# Altium schematic sheet MainSchematic.SchDoc
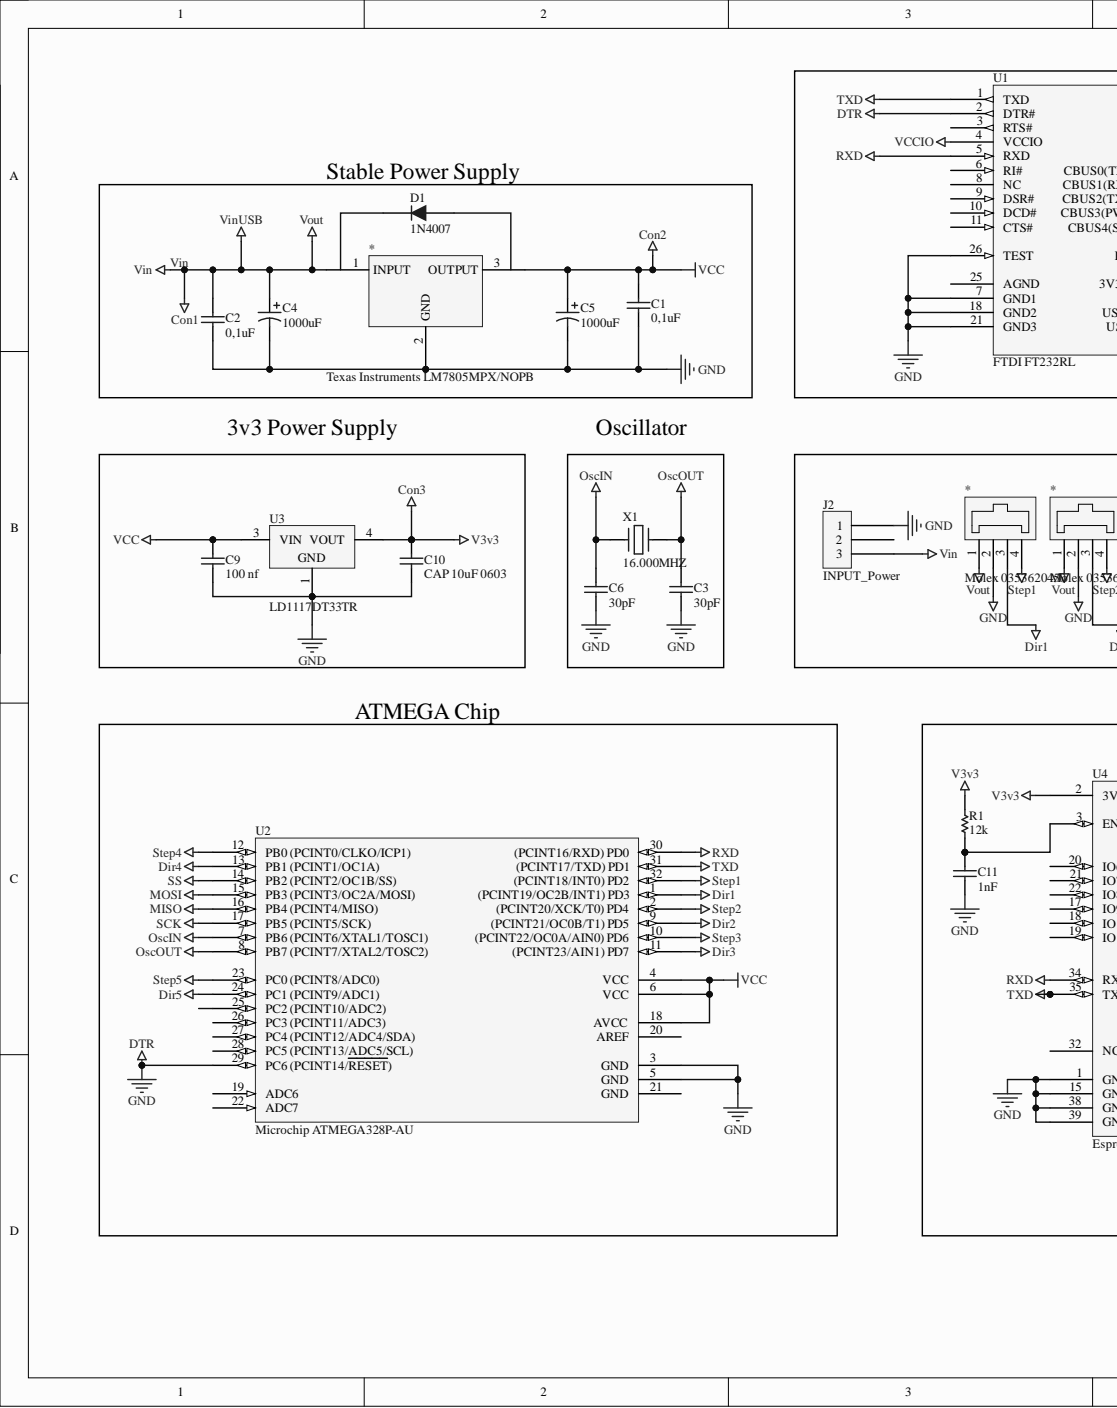
[diagram p0: MainSchematic.SchDoc, left]
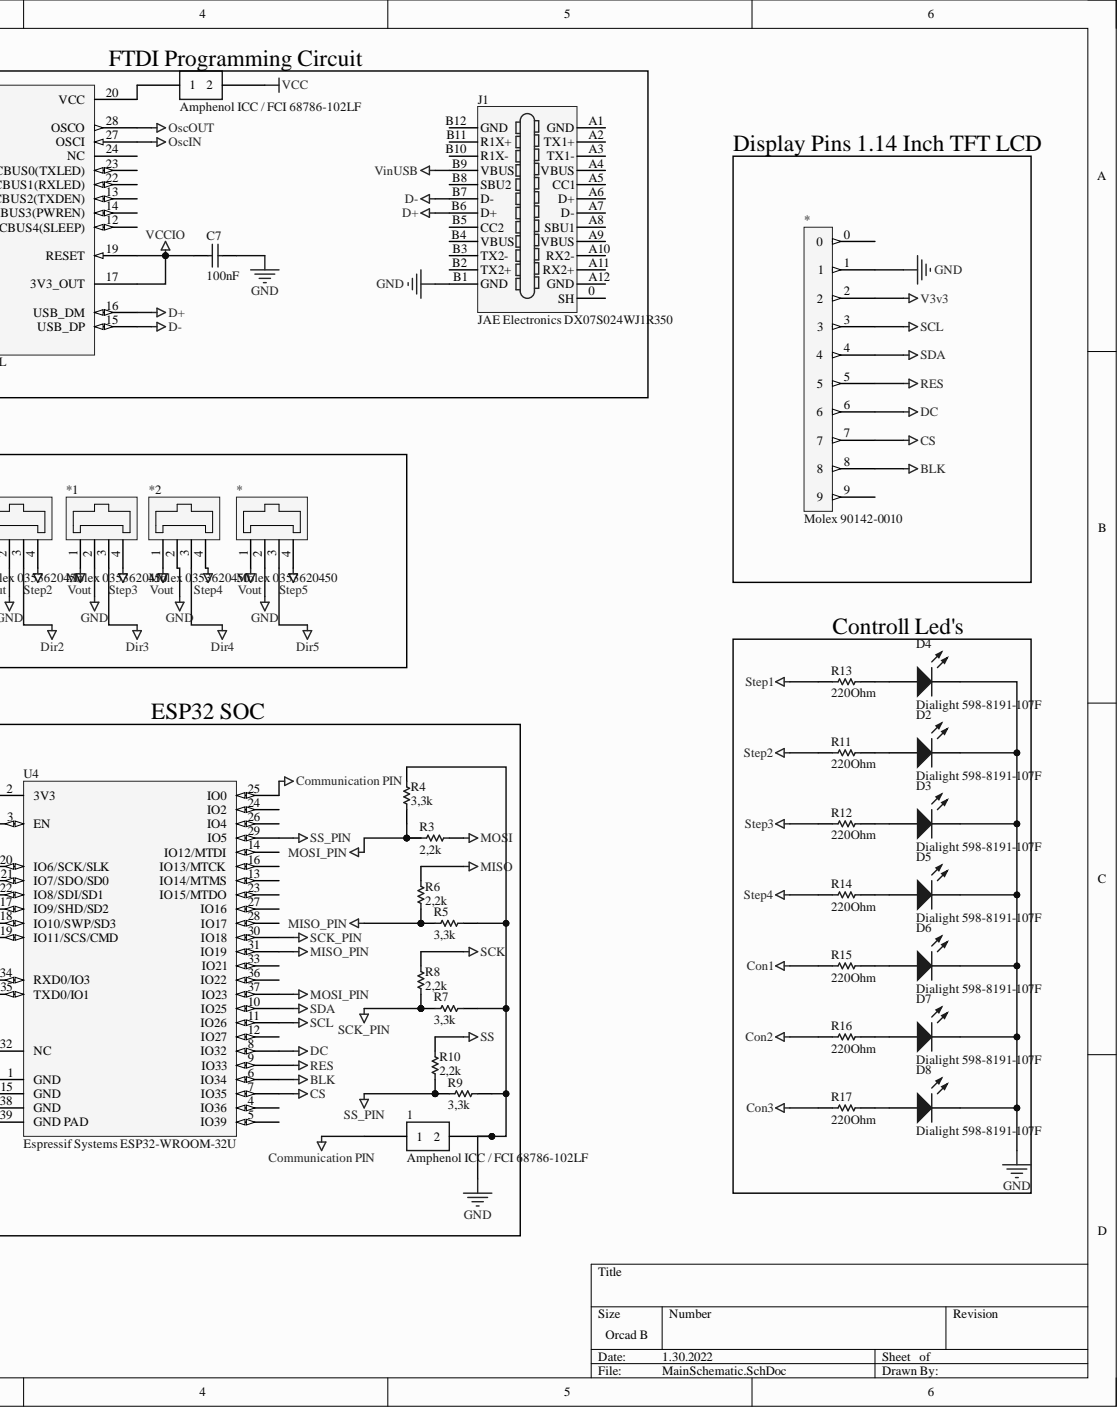
[diagram p1: MainSchematic.SchDoc, right]

## Parts
1  Amphenol ICC / FCI 68786-102LF  Manufacturer Part Number: 68786-102LF  [Amphenol ICC _ FCI 68786-102LF-Footprint-1]
*  Molex 0353620450  Manufacturer Part Number: 0353620450  [PCB-dbci3ib1q6wlta0cp8e4-1]
*  Molex 0353620450  Manufacturer Part Number: 0353620450  [PCB-dbci3ib1q6wlta0cp8e4-1]
*  Molex 0353620450  Manufacturer Part Number: 0353620450  [PCB-dbci3ib1q6wlta0cp8e4-1]
*  Molex 90142-0010  [Molex 90142-0006-Footprint-2]
*  Texas Instruments LM7805MPX/NOPB  Manufacturer Part Number: LM7805MPX/NOPB  [PCB-4j8pz0fvsyjao2wd9t55-1]
*1  Molex 0353620450  Manufacturer Part Number: 0353620450  [PCB-dbci3ib1q6wlta0cp8e4-1]
*2  Molex 0353620450  Manufacturer Part Number: 0353620450  [PCB-dbci3ib1q6wlta0cp8e4-1]
C1  0,1uF  [PCB-ygfjbn9s2h0hdffghsun-1]
C2  0,1uF  [PCB-ygfjbn9s2h0hdffghsun-1]
C3  30pF  [CAPC1608X92X38ML15T08]
C4  1000uF  [PCB-6nlarhmhqnsp8hp5opt1-1]
C5  1000uF  [PCB-6nlarhmhqnsp8hp5opt1-1]
C6  30pF  [CAPC1608X92X38ML15T08]
C7  100nF  [PCB-ami98eyguu81f02oljzp-1]
C9  100 nf  [PCB-ygyach2j9q55hm6do3nn-1]
C10  CAP 10uF 0603  value 10uF  [PCB-wvd42uoi0udsmgfukqhe-1]
C11  1nF  [CAPC3216X88X50NL20T25]
D1  1N4007  [PCB-kzeakw2snxr54lsx6p55-1]
D2  Dialight 598-8191-107F  Manufacturer Part Number: 598-8191-107F  [PCB-8gfa86omdps060zikj8u-1]
D3  Dialight 598-8191-107F  Manufacturer Part Number: 598-8191-107F  [PCB-8gfa86omdps060zikj8u-1]
D4  Dialight 598-8191-107F  Manufacturer Part Number: 598-8191-107F  [PCB-8gfa86omdps060zikj8u-1]
D5  Dialight 598-8191-107F  Manufacturer Part Number: 598-8191-107F  [PCB-8gfa86omdps060zikj8u-1]
D6  Dialight 598-8191-107F  Manufacturer Part Number: 598-8191-107F  [PCB-8gfa86omdps060zikj8u-1]
D7  Dialight 598-8191-107F  Manufacturer Part Number: 598-8191-107F  [PCB-8gfa86omdps060zikj8u-1]
D8  Dialight 598-8191-107F  Manufacturer Part Number: 598-8191-107F  [PCB-8gfa86omdps060zikj8u-1]
J1  JAE Electronics DX07S024WJ1R350  Manufacturer: JAE Electronics  Manufacturer Part Number: DX07S024WJ1R350  [PCB-c92dq56pmj9hhsl6x7py-1]
J2  INPUT_Power  [PCB-6ccby9ci1emhqvhnf7pu-1]
R1  12k  [PCB-ag4xodjlqx01vhs1m206-1]
R3  2,2k  [RESC1005X37X25LL05T10]
R4  3,3k  [RESC1608X55X25LL10T15]
R5  3,3k  [RESC1608X55X25LL10T15]
R6  2,2k  [RESC1005X37X25LL05T10]
R7  3,3k  [RESC1608X55X25LL10T15]
R8  2,2k  [RESC1005X37X25LL05T10]
R9  3,3k  [RESC1608X55X25LL10T15]
R10  2,2k  [RESC1005X37X25LL05T10]
R11  220Ohm  [RESC1608X55X30LL15T15]
R12  220Ohm  [RESC1608X55X30LL15T15]
R13  220Ohm  [RESC1608X55X30LL15T15]
R14  220Ohm  [RESC1608X55X30LL15T15]
R15  220Ohm  [RESC1608X55X30LL15T15]
R16  220Ohm  [RESC1608X55X30LL15T15]
R17  220Ohm  [RESC1608X55X30LL15T15]
U1  FTDI FT232RL  Manufacturer Part Number: FT232RL  [PCB-uwrbgvod66r8ixue8rhm-1]
U2  Microchip ATMEGA328P-AU  [PCB-pmhtxxix7sripjdh33vl-1]
U3  LD1117DT33TR  [TO-252_N]
U4  Espressif Systems ESP32-WROOM-32U  [PCB-s9cahnfxwmssy5lrdql1-1]
X1  16.000MHZ  [PCB-m5wiadh0ra6h79h7klez-1]
  Amphenol ICC / FCI 68786-102LF  Manufacturer Part Number: 68786-102LF  [Amphenol ICC _ FCI 68786-102LF-Footprint-1]

## Nets
BLK: *.8 U4.6(IO34)
Communication PIN: 1.1 U4.25(IO0)
Con2: *.3 C1.1 C5.1 C9.2 R16.2 U2.4(VCC) U2.6(VCC) U2.18(AVCC) U3.3 .2
Con3: *.2 C10.2 R1.2 R17.2 U3.4 U4.2(3V3)
CS: *.7 U4.7(IO35)
D+: J1.B6(D+) U1.16(USB_DM)
D-: J1.B7(D-) U1.15(USB_DP)
DC: *.6 U4.8(IO32)
Dir1: *.3 U2.1((PCINT19/OC2B/INT1)_PD3)
Dir2: *.3 U2.9((PCINT21/OC0B/T1)_PD5)
Dir3: *1.3 U2.11((PCINT23/AIN1)_PD7)
Dir4: *2.3 U2.13(PB1_(PCINT1/OC1A))
Dir5: *.3 U2.24(PC1_(PCINT9/ADC1))
DTR: 1.2 *.1 *.2 *1.2 *2.2 C1.2 C2.1 C3.1 C4.2 C5.2 C6.1 C7.2 C9.1 C10.1 C11.2 D2.K D3.K D4.K D5.K D6.K D7.K D8.K J1.B1(GND) J2.1 R4.2 R5.1 R7.1 R9.1 U1.2(DTR#) U1.7(GND1) U1.18(GND2) U1.21(GND3) U1.26(TEST) U2.3(GND) U2.5(GND) U2.29(PC6_(PCINT14/RESET)) U3.1 U4.1(GND) U4.15(GND) U4.38(GND) U4.39(GND_PAD)
MISO: R6.1 U2.16(PB4_(PCINT4/MISO))
MISO_PIN: R5.2 R6.2 U4.31(IO19)
MOSI: R3.1 U2.15(PB3_(PCINT3/OC2A/MOSI))
MOSI_PIN: R3.2 R4.1 U4.37(IO23)
Net_1: U1.20(VCC) .1
OscIN: C6.2 U1.27(OSCI) U2.7(PB6_(PCINT6/XTAL1/TOSC1)) X1.1
OscOUT: C3.2 U1.28(OSCO) U2.8(PB7_(PCINT7/XTAL2/TOSC2)) X1.2
RES: *.5 U4.9(IO33)
RXD: U1.5(RXD) U2.30((PCINT16/RXD)_PD0) U4.34(RXD0/IO3)
SCK: R8.1 U2.17(PB5_(PCINT5/SCK))
SCK_PIN: R7.2 R8.2 U4.30(IO18)
SCL: *.3 U4.11(IO26)
SDA: *.4 U4.10(IO25)
SS: R10.1 U2.14(PB2_(PCINT2/OC1B/SS))
SS_PIN: R9.2 R10.2 U4.29(IO5)
Step1: *.4 R13.2 U2.32((PCINT18/INT0)_PD2)
Step2: *.4 R11.2 U2.2((PCINT20/XCK/T0)_PD4)
Step3: *1.4 R12.2 U2.10((PCINT22/OC0A/AIN0)_PD6)
Step4: *2.4 R14.2 U2.12(PB0_(PCINT0/CLKO/ICP1))
Step5: *.4 U2.23(PC0_(PCINT8/ADC0))
TXD: U1.1(TXD) U2.31((PCINT17/TXD)_PD1) U4.35(TXD0/IO1)
VCCIO: C7.1 U1.4(VCCIO) U1.17(3V3_OUT) U1.19(RESET)
Vin: *.1 *1.1 *2.1 C2.2 C4.1 J1.B9(VBUS) J2.3 R15.2
NetC11_1: C11.1 R1.1 U4.3(EN)
NetD2_A: D2.A R11.1
NetD3_A: D3.A R12.1
NetD4_A: D4.A R13.1
NetD5_A: D5.A R14.1
NetD6_A: D6.A R15.1
NetD7_A: D7.A R16.1
NetD8_A: D8.A R17.1

Not connected: * 0 9; D1 1 2; J1 0(SH) A1(GND) A2(TX1+) A3(TX1-) A4(VBUS) A5(CC1) A6(D+) A7(D-) A8(SBU1) A9(VBUS) A10(RX2-) A11(RX2+) A12(GND) B2(TX2+) B3(TX2-) B4(VBUS) B5(CC2) B8(SBU2) B10(R1X-) B11(R1X+) B12(GND); J2 2; U1 3(RTS#) 6(RI#) 8(NC) 9(DSR#) 10(DCD#) 11(CTS#) 12(CBUS4(SLEEP)) 13(CBUS2(TXDEN)) 14(CBUS3(PWREN)) 22(CBUS1(RXLED)) 23(CBUS0(TXLED)) 24(NC) 25(AGND); U2 19(ADC6) 20(AREF) 21(GND) 22(ADC7) 25(PC2_(PCINT10/ADC2)) 26(PC3_(PCINT11/ADC3)) 27(PC4_(PCINT12/ADC4/SDA)) 28(PC5_(PCINT13/ADC5/SCL)); U4 4(IO36) 5(IO39) 12(IO27) 13(IO14/MTMS) 14(IO12/MTDI) 16(IO13/MTCK) 17(IO9/SHD/SD2) 18(IO10/SWP/SD3) 19(IO11/SCS/CMD) 20(IO6/SCK/SLK) 21(IO7/SDO/SD0) 22(IO8/SDI/SD1) 23(IO15/MTDO) 24(IO2) 26(IO4) 27(IO16) 28(IO17) 32(NC) 33(IO21) 36(IO22)

## Library data of the parts
1  = CMP-3e4bf223796ce18c-1: connector; 1x2 low profile jumper assembly; gold plated cont; polyester body; Lead-Free Status: Lead Free; Color: White; Component Kind: Standard; Contact Plating: Gold; Library Name: My Personal Space; Octopart Url: https://octopart.com/68786-102lf-amphenol+icc+%2F+fci-90333761; OctopartID: 3e4bf223796ce18c; RoHS: Compliant
* * * *1 *2 = CMP-b14b78de26816e8c-4: Conn Shrouded Header HDR 4 POS 2mm Solder ST Thru-Hole SherlockÃ¢âÂ¢ Bag; Gender: Male; Component Kind: Standard; Library Name: My Personal Space; Lifecycle Status: Not Listed by Manufacturer; Mounting Style: Solder; Octopart URL: http://octopart.com/0353620450-molex-10465964; OctopartID: b14b78de26816e8c; Packaging: Bulk; RoHS: Compliant
* = CMP-a2626b6ccecb7da5-2: 2.54mm Pitch C-Grid III Crimp Housing Dual Row, 8 Circuits, Black; Manufacturer Part Number: 90142-0008; Contact Resistance: 20.0 mΩ; Current Rating: 3.00 A; Gender: Female; Glow Wire Compliant: Non-Compliant; Insulation Resistance: 5.00 GΩ; Lead-Free Status: Lead Free; Number of Contacts: 8; Voltage Rating (AC): 350 V; Color: Black; Component Kind: Standard; Flammability Rating: UL 94 V-0; Halogen Free Status: Not Reviewed; Housing Color: Black; Library Name: My Personal Space; Lifecycle Status: Active; Mounting Style: PCB; Number of Positions: 8; Number of Rows: 2; Octopart Url: https://octopart.com/90142-0008-molex-281039; OctopartID: a2626b6ccecb7da5; Operating Temperature: 105 °C (max); Packaging: Bag; Pin Pitch: 2.54 mm; REACH SVHC Compliance: No SVHC; RoHS: Compliant; Size-Height: 7.72 mm; Size-Length: 10.3 mm; Size-Width: 15.2 mm
* = CMP-00afcdd7e6a1df68-3: 1.5-A, Wide VIN Fixed Voltage Regulators 4-SOT-223 0 to 0; Dropout Voltage: 2.00 V; Lead-Free Status: Contains Lead; Number of Regulated Outputs: 1; Output Capacitor Type: Ceramic; Quiescent Current: 6.00 mA; Case/Package: SOT-223; Component Kind: Standard; Library Name: My Personal Space; Lifecycle Status: Active; Number of Pins: 4; Octopart URL: https://octopart.com/lm7805mpx%2Fnopb-texas+instruments-74629992; OctopartID: 00afcdd7e6a1df68; Operating Temperature: 0.00 °C to 125 °C; RoHS: Compliant
C1 C2 = CMP-230febb915d7fcc8-1: 0603 0.1 uF 35 V ±10 % Tolerance X7R Surface Mount Multilayer Ceramic Capacitor; Manufacturer Part Number: GMK107B7104KAHT; Capacitance: 100 nF; Capacitance Tolerance: ±10%; Lead-Free Status: Lead Free; Voltage Rating (DC): 35.0 V; Case/Package: 0603; Component Kind: Standard; Halogen Free Status: Halogen Free; Library Name: My Personal Space; Lifecycle Status: Active; Mounting Style: Surface Mount; Octopart URL: https://octopart.com/gmk107b7104kaht-taiyo+yuden-29103038; OctopartID: 230febb915d7fcc8; Packaging: Cut Tape (CT); RoHS: Compliant
C3 C6 = CMP-3554665-2: Multilayer Ceramic Capacitors MLCC - SMD/SMT 0603 30pF 250V C0G 5% HI FREQ; Manufacturer Part Number: VJ0603D300JXPAJ; Capacitance: 3e-11 F; Dielectric Characteristic: C0G/NP0; Lead-Free Status: Lead Free; Voltage Rating (DC): 250.0 V; Capacitance Tolerance: ±5%; Component Kind: Standard; Library Name: My Personal Space; Octopart Url: https://octopart.com/vj0603d300jxpaj-vishay-45210262; OctopartID: a54f6ef45abf7a88; Packaging: Cut Tape (CT); RoHS: Compliant
C4 C5 = CMP-ee612768d29831a6-2: 1000uF 6.3 V Aluminium Electrolytic Capacitor; WT Series 1000h 8 (Dia.) x 10mm; Manufacturer Part Number: UWT0J102MNL1GS; Capacitance: 1.00 mF; Capacitance Tolerance: ±20%; Dielectric Material: Aluminum Electrolytic; Lead-Free Status: Lead Free; Voltage Rating (DC): 6.30 V; Component Kind: Standard; Library Name: My Personal Space; Mounting Style: Surface Mount; Number of Pins: 2; Octopart URL: https://octopart.com/uwt0j102mnl1gs-nichicon-203167; OctopartID: ee612768d29831a6; Operating Temperature: 105 °C (max); Packaging: Cut Tape (CT), Tape & Reel (TR); RoHS: Compliant; Size-Diameter: 8.00 mm; Size-Height: 10.0 mm; Size-Length: 10.0 mm
C7 = CMP-7716bc466958a8d1-1: 1206 100000 pF 50V ±10% Tolerance X7R Surface Mount Multilayer Ceramic Capacitor; Manufacturer Part Number: CC1206KRX7R9BB104; Case/Package (SI): 3216; Insulation Resistance: 100 GΩ; Lead-Free Status: Lead Free; Voltage Rating (DC): 50.0 V; Capacitance: 100 nF; Capacitance Tolerance: ±10%; Case/Package: 1206; Component Kind: Standard; Dielectric Characteristic: X7R; Halogen Free Status: Halogen Free; Library Name: My Personal Space; Mounting Style: Surface Mount; Number of Pins: 2; Octopart URL: http://octopart.com/cc1206krx7r9bb104-yageo-39467816; OctopartID: 7716bc466958a8d1; Packaging: Cut Tape (CT), Tape & Reel (TR); REACH SVHC Compliance: No SVHC; RoHS: Compliant; Size-Height: 1.14 mm; Size-Length: 3.20 mm; Size-Width: 1.60 mm
C9 = CMP-1af8d38ac64bc53f-10: Ceramic capacitor SMD-0603 100nF /50V X7R# K +-10%, Samsung, RoHS; Manufacturer Part Number: CL10B104KB8NNNC; Capacitance Tolerance: ±10%; Dielectric Characteristic: X7R; Lead-Free Status: Lead Free; Voltage Rating (DC): 50.0 V; Capacitance: 100 nF; Case/Package: 0603; Component Kind: Standard; Library Name: My Personal Space; Mounting Style: Surface Mount; Octopart URL: https://octopart.com/cl10b104kb8nnnc-samsung-7928277; OctopartID: 1af8d38ac64bc53f; RoHS: Compliant
C10 = CMP-121611-5: C Series 0603 10 uF 25 V ±10 % Tolerance X5R Multilayer Ceramic Capacitor; Manufacturer: Murata; Part Number: GRM188R61E106KA73J; Ex Price: 0.36; Tolerance: 10%; VN Price: 850; Voltage/Wattage: 25V; Component Kind: Standard; Ex Supplier: https://www.mouser.vn/ProductDetail/Murata-Electronics/GRM188R61E106KA73J?qs=sGAEpiMZZMukHu%252BjC5l7YUSokBdqpA2iyRK88YQudCM%3D; Library Name: My Personal Space; OctopartID: a02668a768b37fe1; Package: 0603; VN Supplier: https://www.thegioiic.com/products/tu-gom-0603-10uf-25v
C11 = CMP-8261919-2: Multilayer Ceramic Capacitors MLCC - SMD/SMT 50volts 1000pF 10% X7R; Manufacturer Part Number: C1206C102K5RAC; Capacitance: 1e-09 F; Case/Package (SI): 3216; Lead-Free Status: R; Voltage Rating (DC): 50.0 V; Capacitance Tolerance: ±10%; Case/Package: 1206; Component Kind: Standard; Dielectric Characteristic: X7R; Dielectric Material: Ceramic; Library Name: My Personal Space; Mounting Style: Surface Mount; Number of Pins: 2; Octopart Url: https://octopart.com/c1206c102k5rac-kemet-967170; OctopartID: 80a249a667c65f65; RoHS: Compliant
D1 = CMP-9114309-20: 1N4007 Series 1000 V 1 A Axial Lead Standard Recovery Rectifier - CASE 59-10; Manufacturer Part Number: 1N4007G; Breakdown Voltage: 1.00 kV (max); Current Rating: 1.00 A; Forward Voltage: 1.10 V; Lead-Free Status: Lead Free; Output Current: 1.00 A (max); Voltage Rating (DC): 1.00 kV; Case/Package: DO-204; Component Kind: Standard; Halogen Free Status: Halogen Free; Library Name: My Personal Space; Lifecycle Status: Active; Mounting Style: Axial; Number of Pins: 2; Octopart URL: https://octopart.com/1n4007g-on+semiconductor-6031; OctopartID: ffb836b2cd51207f; Operating Temperature: -65.0 °C to 175 °C; Packaging: Bulk; Polarity: Standard; REACH SVHC Compliance: No SVHC; RoHS: Compliant
D2-D8 = CMP-90265921f4414fe1-1: 598 Series Blue 2 x 1.25 x 0.7 mm 140° Water Clear 140 mcd 3.2 V SMT LED; Case/Package (SI): 2012; Forward Voltage: 3.20 V; Lead-Free Status: Lead Free; Lens Type: Non-Diffused; Luminous Intensity: 140 mcd; Wavelength: 475 nm, 473 nm; Case/Package: 0805; Color: Blue; Component Kind: Standard; Library Name: My Personal Space; Mounting Style: Surface Mount; Number of Pins: 2; Octopart URL: http://octopart.com/598-8191-107f-dialight-868609; OctopartID: 90265921f4414fe1; Packaging: Reel; RoHS: Compliant; Size-Height: 700 µm; Size-Length: 2.00 mm; Size-Width: 1.25 mm; Viewing Angle: 140 °
J1 = CMP-100bdd7e1ee2cfcc-1: Vertical Mount Type C receptacle; 12mm Height; Case/Package: USB TYPE-C Vertical Mount; Component Kind: Standard; Library Name: My Personal Space; Octopart URL: https://octopart.com/dx07s024wj1r350-jae+electronics-75443087; OctopartID: 100bdd7e1ee2cfcc; Supplier: Digi-Key; Supplier Part Number: 670-2949-2-ND
J2 = CMP-751491-6: Conn Terminal Block 3 POS 2.54mm Solder ST Thru-Hole 10A/Contact; Manufacturer Part Number: 282834-3; ELV Compliant: Compliant; Lead-Free Status: Lead Free; Number of Contacts: 3; Wire Gauge: 16.0 AWG to 30.0 AWG; Color: Green; Component Kind: Standard; Contact Material: Brass; Contact Plating: Tin; Flammability Rating: UL 94 V-0; Housing Color: Green; Housing Material: Nylon 6/6; Library Name: My Personal Space; Lifecycle Status: Active; Mounting Style: Through Hole, Screw; Number of Positions: 3; Number of Rows: 1; Octopart URL: https://octopart.com/282834-3-te+connectivity-39539741; OctopartID: 2bf4e0a55a8ff433; Operating Temperature: -40.0 °C to 110 °C; Orientation: Right Angle; Packaging: Bulk; Pin Pitch: 2.54 mm; REACH SVHC Compliance: No SVHC; RoHS: Compliant; Size-Height: 10.0 mm; Size-Length: 8.08 mm; Size-Width: 6.50 mm
R1 = CMP-ad5c66e3363d729b-1: Res Thick Film 2512 12K Ohm 1% 2W ±100ppm/C Pad SMD Automotive T/R; Manufacturer Part Number: 352112KFT; ELV Compliant: Compliant; Lead-Free Status: Lead Free; Power Rating: 2.00 W; Resistance: 12.0 kΩ; Temperature Coefficient: -100 ppm/°C to 100 ppm/°C; Voltage Rating (DC): 250 V; Component Kind: Standard; Library Name: My Personal Space; Lifecycle Status: Not Listed by Manufacturer; Mounting Style: Surface Mount; Octopart URL: https://octopart.com/352112kft-te+connectivity-45465095; OctopartID: ad5c66e3363d729b; Packaging: Tape & Reel (TR); REACH SVHC Compliance: No SVHC; Resistance Tolerance: ±1%; RoHS: Compliant
R3 R6 R8 R10 = CMP-7833842-2: Thick Film Resistors - SMD 1/16W 3.3K ohm 1%; Manufacturer Part Number: AC0402FR-073K3L; Lead-Free Status: Lead Free; Resistance: 3300.0 Ω; Case/Package: 0402; Component Kind: Standard; Library Name: My Personal Space; Mounting Style: Surface Mount; Octopart Url: https://octopart.com/ac0402fr-073k3l-yageo-24618178; OctopartID: ace0649d2d447327; Resistance Tolerance: ±1%; RoHS: Compliant
R4 R5 R7 R9 = CMP-1872096-2: RES SMD 2.2K OHM 1% 1/10W 0603; Manufacturer Part Number: AC0603FR-072K2L; Lead-Free Status: Lead Free; Resistance: 2200.0 Ω; Case/Package: 0603; Component Kind: Standard; Library Name: My Personal Space; Mounting Style: Surface Mount; Octopart Url: https://octopart.com/ac0603fr-072k2l-yageo-21711100; OctopartID: 1dc9d5887053c20e; Resistance Tolerance: ±1%; RoHS: Compliant
R11-R17 = CMP-3654704-2: Thick Film Resistors 0603 220ohms 5% Tol; Manufacturer Part Number: ERJ-3GEYJ221V; Lead-Free Status: Lead Free; Power Rating: 0.1 W; Resistance: 220.0 Ω; Voltage Rating (DC): 75.0 V; Case/Package: 0603; Component Kind: Standard; Library Name: My Personal Space; Lifecycle Status: Not Listed by Manufacturer; Mounting Style: Surface Mount; Octopart Url: https://octopart.com/erj-3geyj221v-panasonic-55422036; OctopartID: 68a3a2e4e191b764; Packaging: Cut Tape (CT); REACH SVHC Compliance: No SVHC; Resistance Tolerance: ±5%; RoHS: Compliant; Size-Height: 0.00045 m; Size-Length: 0.0016 m; Size-Width: 0.0008 m
U1 = CMP-1159378-22: Usb Interface Ics Usb to Serial Uart 28 Pin SSOP; Bandwidth: 48.0 MHz; Data Rate: 3.00 Mbps; Lead-Free Status: Lead Free; Supply Current: 15.0 mA; Supply Voltage (DC): 1.80 V (min), 5.25 V (max); Component Kind: Standard; Library Name: My Personal Space; Mounting Style: Surface Mount; Number of Pins: 28; Octopart URL: https://octopart.com/ft232rl-ftdi-2151617; OctopartID: bcc675ec4d616301; Operating Temperature: -40.0 °C (min); Packaging: Tube; REACH SVHC Compliance: No SVHC; RoHS: Compliant
U2 = CMP-973185-51: ATmega Series 32 kB Flash 2 kB SRAM 20 MHz 8-Bit Microcontroller - TQFP-32; Component Kind: Standard; Library Name: My Personal Space
U3 = CMP-1248216-2: Fixed Low Drop Positive Voltage Regulator, 3.3V, 3-Pin DPAK, Tape and Reel; Dropout Voltage: 1.1 V; Lead-Free Status: Lead Free; Number of Outputs: 1; Output Current: 0.8 A; Component Kind: Standard; Library Name: My Personal Space; Lifecycle Status: Active; Manufacturer Part Number: LD1117DT33TR; Mounting Style: Surface Mount; Number of Pins: 3; Octopart Url: https://octopart.com/ld1117dt33tr-stmicroelectronics-526721; OctopartID: 07f8cefa2b1fd83f; Packaging: Cut Tape (CT); REACH SVHC Compliance: No SVHC; RoHS: Compliant
U4 = CMP-ba1676706f1765d1-28: WiFi Modules (802.11) SMD Module, ESP32-D0WDQ6, 32Mbits SPI flash, UART Mode; Component Kind: Standard; Library Name: My Personal Space
X1 = CMP-fc9f355d6cd42358-1: CRYSTAL, THT, 16.000MHZ; Frequency: 16MHz; Frequency Tolerance: ± 30ppm; Load Capacitance: 18pF; Frequency Tolerance:...; Manufacturer Part Number: 16.000MHZ HC49/4H/30/50/40/18PF/ATF; Frequency: 16.0 MHz; Load Capacitance: 18.0 pF; Component Kind: Standard; Library Name: My Personal Space; Number of Pins: 2; Octopart URL: https://octopart.com/16.000mhz+hc49%2F4h%2F30%2F50%2F40%2F18pf%2Fatf-euroquartz-13153581; OctopartID: fc9f355d6cd42358; Operating Temperature: -40.0 °C to 85.0 °C; REACH SVHC Compliance: No SVHC; RoHS: Compliant
